# Altium schematic sheet InfraredSystem.SchDoc
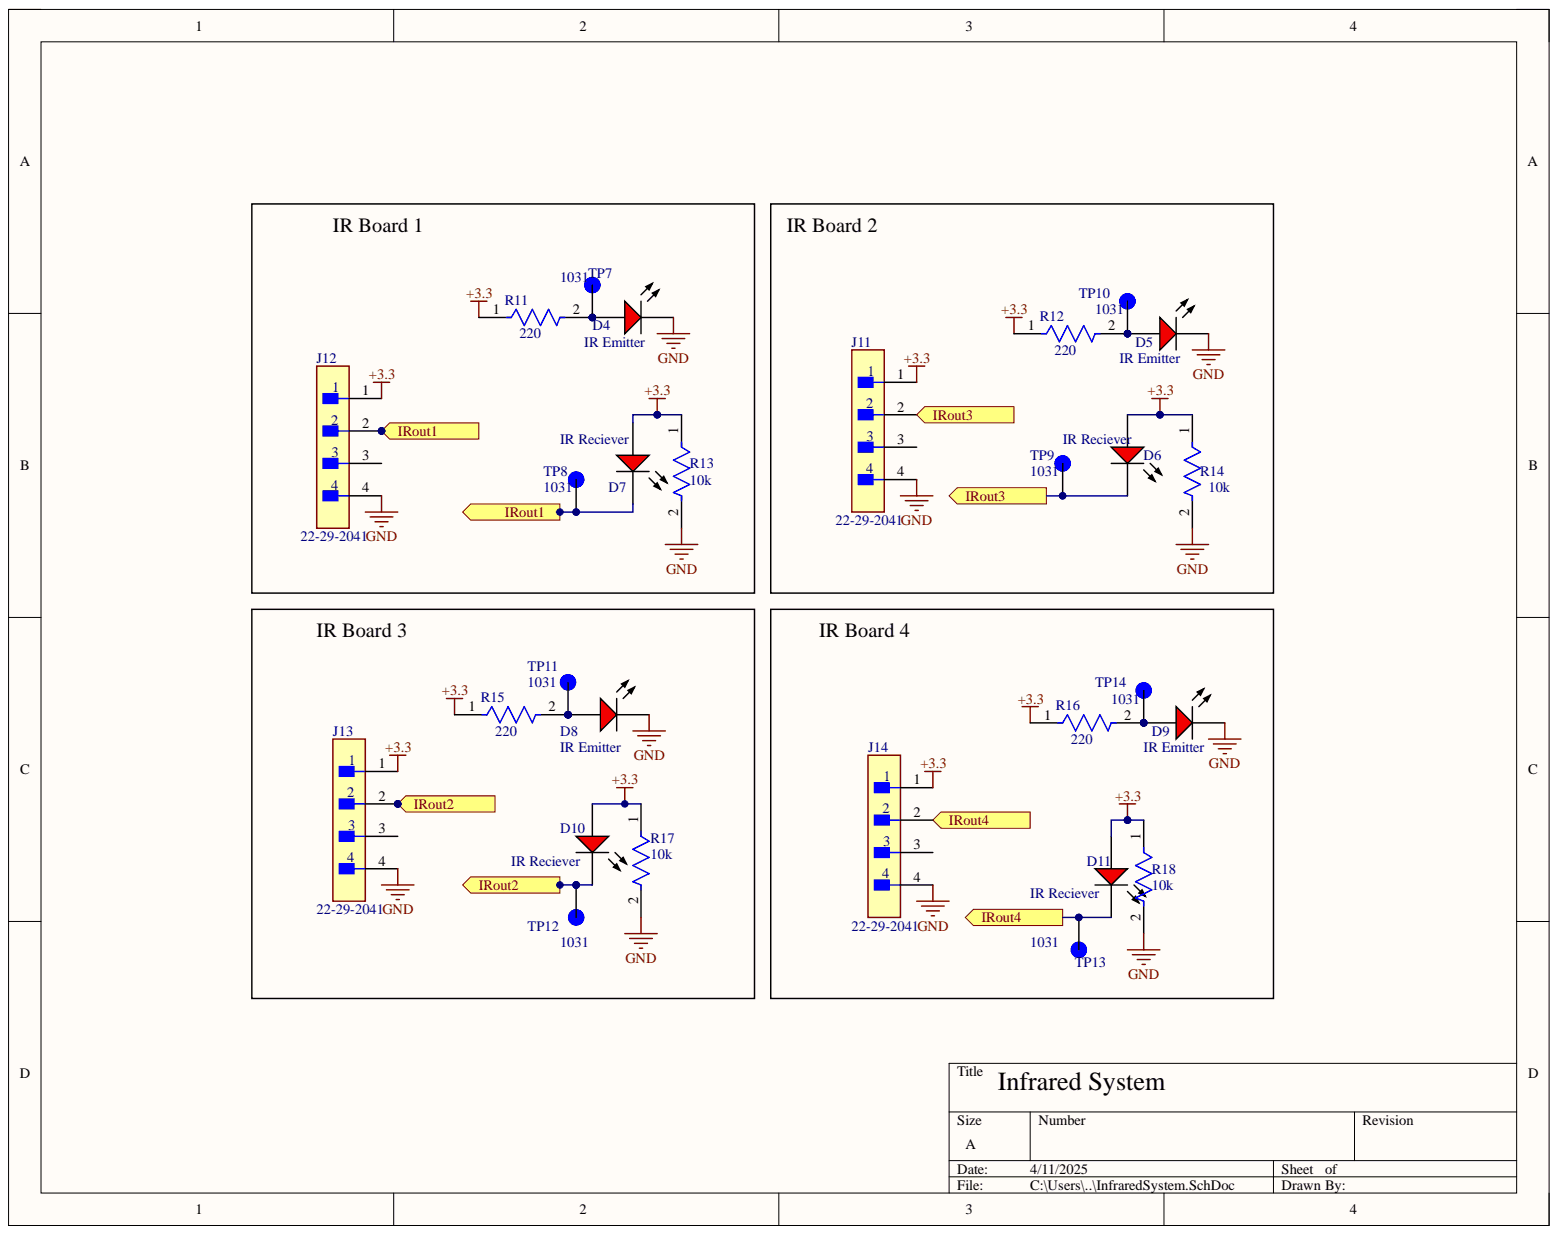
[diagram p0: InfraredSystem.SchDoc]

## Parts
D4  IR Emitter  [AVAG-HLMP-3301-2]
D5  IR Emitter  [AVAG-HLMP-3301-2]
D6  IR Reciever  [AVAG-HLMP-3301-2]
D7  IR Reciever  [AVAG-HLMP-3301-2]
D8  IR Emitter  [AVAG-HLMP-3301-2]
D9  IR Emitter  [AVAG-HLMP-3301-2]
D10  IR Reciever  [AVAG-HLMP-3301-2]
D11  IR Reciever  [AVAG-HLMP-3301-2]
J11  22-29-2041  [FP-22-29-2041-MFG]
J12  22-29-2041  [FP-22-29-2041-MFG]
J13  22-29-2041  [FP-22-29-2041-MFG]
J14  22-29-2041  [FP-22-29-2041-MFG]
R11  220  [FP-CRCW0805-e3-MFG]
R12  220  [FP-CRCW0805-e3-MFG]
R13  10k  [FP-CR0805-IPC_A]
R14  10k  [FP-CR0805-IPC_A]
R15  220  [FP-CRCW0805-e3-MFG]
R16  220  [FP-CRCW0805-e3-MFG]
R17  10k  [FP-CR0805-IPC_A]
R18  10k  [FP-CR0805-IPC_A]
TP7  1031  [KEYSTONE_1031]
TP8  1031  [KEYSTONE_1031]
TP9  1031  [KEYSTONE_1031]
TP10  1031  [KEYSTONE_1031]
TP11  1031  [KEYSTONE_1031]
TP12  1031  [KEYSTONE_1031]
TP13  1031  [KEYSTONE_1031]
TP14  1031  [KEYSTONE_1031]

## Ports
IRout1, IRout2, IRout3, IRout4

## Nets
+3.3: D6.2 D7.2 D10.2 D11.2 J11.1 J12.1 J13.1 J14.1 R11.1 R12.1 R13.1 R14.1 R15.1 R16.1 R17.1 R18.1
GND: D4.1 D5.1 D8.1 D9.1 J11.4 J12.4 J13.4 J14.4 R13.2 R14.2 R17.2 R18.2
IRout1: D7.1 J12.2 TP8.TP ; port IRout1
IRout2: D10.1 J13.2 TP12.TP ; port IRout2
IRout3: D6.1 J11.2 TP9.TP ; port IRout3
IRout4: D11.1 J14.2 TP13.TP ; port IRout4
NetD4_2: D4.2 R11.2 TP7.TP
NetD5_2: D5.2 R12.2 TP10.TP
NetD8_2: D8.2 R15.2 TP11.TP
NetD9_2: D9.2 R16.2 TP14.TP

Not connected: J11 3; J12 3; J13 3; J14 3

## Library data of the parts
D4-D11 = CMP-2000-06411-1: T-1 3/4 (5 mm) Diffused LED Lamp, 1.9 V, -40 to 100 degC, 2-Pin THD, RoHS, Bulk; Manufacturer: Avago; Chip Material: GaP; DELETED: Compliant; Dominant Wave Length: 626nm; Emitting Color: Red; Forward Current: 25mA; Forward Voltage: 2.4V; High Brightness: No; Lens Type: ColoredDiffused; Lum Intensity: 5.4mcd; Main Category: StandardLED; Number of  Elements: 1; Peak Wavelength: 635nm; Power Dissipation: 85W; Product Depth (mm): 6.1mm; Product Height (mm): 9.19mm; Reverse Voltage: 5V; Shape Type: Circular; Test Current (It): 10mA; Type: Uni-Color; Viewing Angle: 60deg; Component Kind: Standard; Datasheet URL: http://www.avagotech.com/docs/AV02-1558EN; Datasheet Version: 03/2015; Lens Dimensions: 5.08x5.08x8.3mm; Library Name: Altium Content Vault; Luminous Intensity: 5.4mcd; Manufacturer URL: http://www.avagotech.com/; Mounting: ThroughHole; Mounting Technology: ThroughHole; Operating Temp Range: -40Cto100C; Operating Temperature Classification: Industrial; Package Description: 2-Pin Through Hole LED, Dia 5.85 mm; Package Reference: HLMP-3301; Package Type: T-13/4; Packaging: TapeandReel; Pin Count: 2; Product Length (mm): 6.1mm; Rad Hardened: No
J11-J14 = CMP-04776-000366-1: CONN HEADER VERT 4POS 2.54MM; Contact Resistance: 10mR; Current Rating: 4A; Gender: Male; Glow Wire Compliant: Non-compliant; Insulation Resistance: 50GR; Number of Circuits: 4; Number of Lines: 1; Voltage Rating: 250V; Color: Natural; Component Kind: Standard; Contact Gender: Male; Contact Material: Brass; Contact Mating Length: 0.295inch; Contact Plating: Gold; Contact Type: Male Pin; Depth: 5.8mm; Fastening Type: Friction; Flammability Rating: UL94 V-0; Halogen Free: Low Halogen; Height: 11.7mm; Housing Color: White; Housing Material: Nylon; Lead Free: Lead Free; Length: 10.16mm; Library Name: Altium Content Vault; Max Current Rating: 4A; Max Operating Temperature: 75°C; Min Operating Temperature: 0°C; Mount: Through Hole; Number of Contacts: 4; Number of Poles: 4; Number of Positions: 4; Number of Rows: 1; Orientation: Straight; Package Quantity: 1500; Packaging: Bulk; Pitch: 2.54mm; Radiation Hardening: No; RoHS Compliant: Yes; Series: KK® 6410; Termination: Solder; Voltage Rating (DC): 250V
R11 R12 R15 R16 = CMP-2001-03682-2: RES Thick Film, 220Ω, 1%, 0.125W, 100ppm/°C, 0805; Max Power Dissipation: 125mW; Resistance: 220R; Voltage Rating: 150V; Case Code (Imperial): 0805; Case Code (Metric): 2012; Case/Package: 0805; Component Kind: Standard; Composition: Thick Film; Contact Plating: Tin; Depth: 1.25mm; Features: Automotive AEC-Q200; Height: 0.45mm; Lead Free: Lead Free; Length: 2mm; Library Name: Altium Content Vault; Max Operating Temperature: 155°C; Military Standard: Not; Min Operating Temperature: -55°C; Mount: Surface Mount; Number of Terminations: 2; Package Quantity: 10; Packaging: Tape and Reel; Power Rating: 125mW; Radiation Hardening: No; Temperature Coefficient: 100ppm/°C; Termination: SMD/SMT; Tolerance: 1%; Voltage Rating (DC): 150V; Weight: 0.000194oz; Width: 0.049inch
R13 R14 R17 R18 = CMP-07231-003204-1: Thick Film Chip Resistors 0805 10kΩ 0.125W 1% 100ppm/°C; Max Power Dissipation: 125mW; Resistance: 10kR; Voltage Rating: 150V; Voltage Rating (DC): 100V; Case Code (Imperial): 0805; Case Code (Metric): 2012; Case/Package: 0805; Component Kind: Standard; Composition: Thick Film; Contact Plating: Tin; Depth: 1.25mm; Features: Moisture Resistant; Height: 0.5mm; Length: 2mm; Library Name: Altium Content Vault; Max Operating Temperature: 155°C; Min Operating Temperature: -55°C; Mount: Surface Mount; Number of Terminations: 2; Package Quantity: 1; Packaging: Tape and Reel; Power Rating: 125mW; Radiation Hardening: No; REACH SVHC: No SVHC; Temperature Coefficient: 100ppm/°C; Termination: SMD/SMT; Thickness: 508um; Tolerance: 1%; Width: 1.25mm
TP7-TP14 = 1031: Availability: Unavailable; Component Kind: Standard; Library Name: 1031.IntLib; MP: 1031; Package: None; Price: None
